# Altium schematic sheet wls2-inkScreen.SchDoc(wls2-inkScreen)
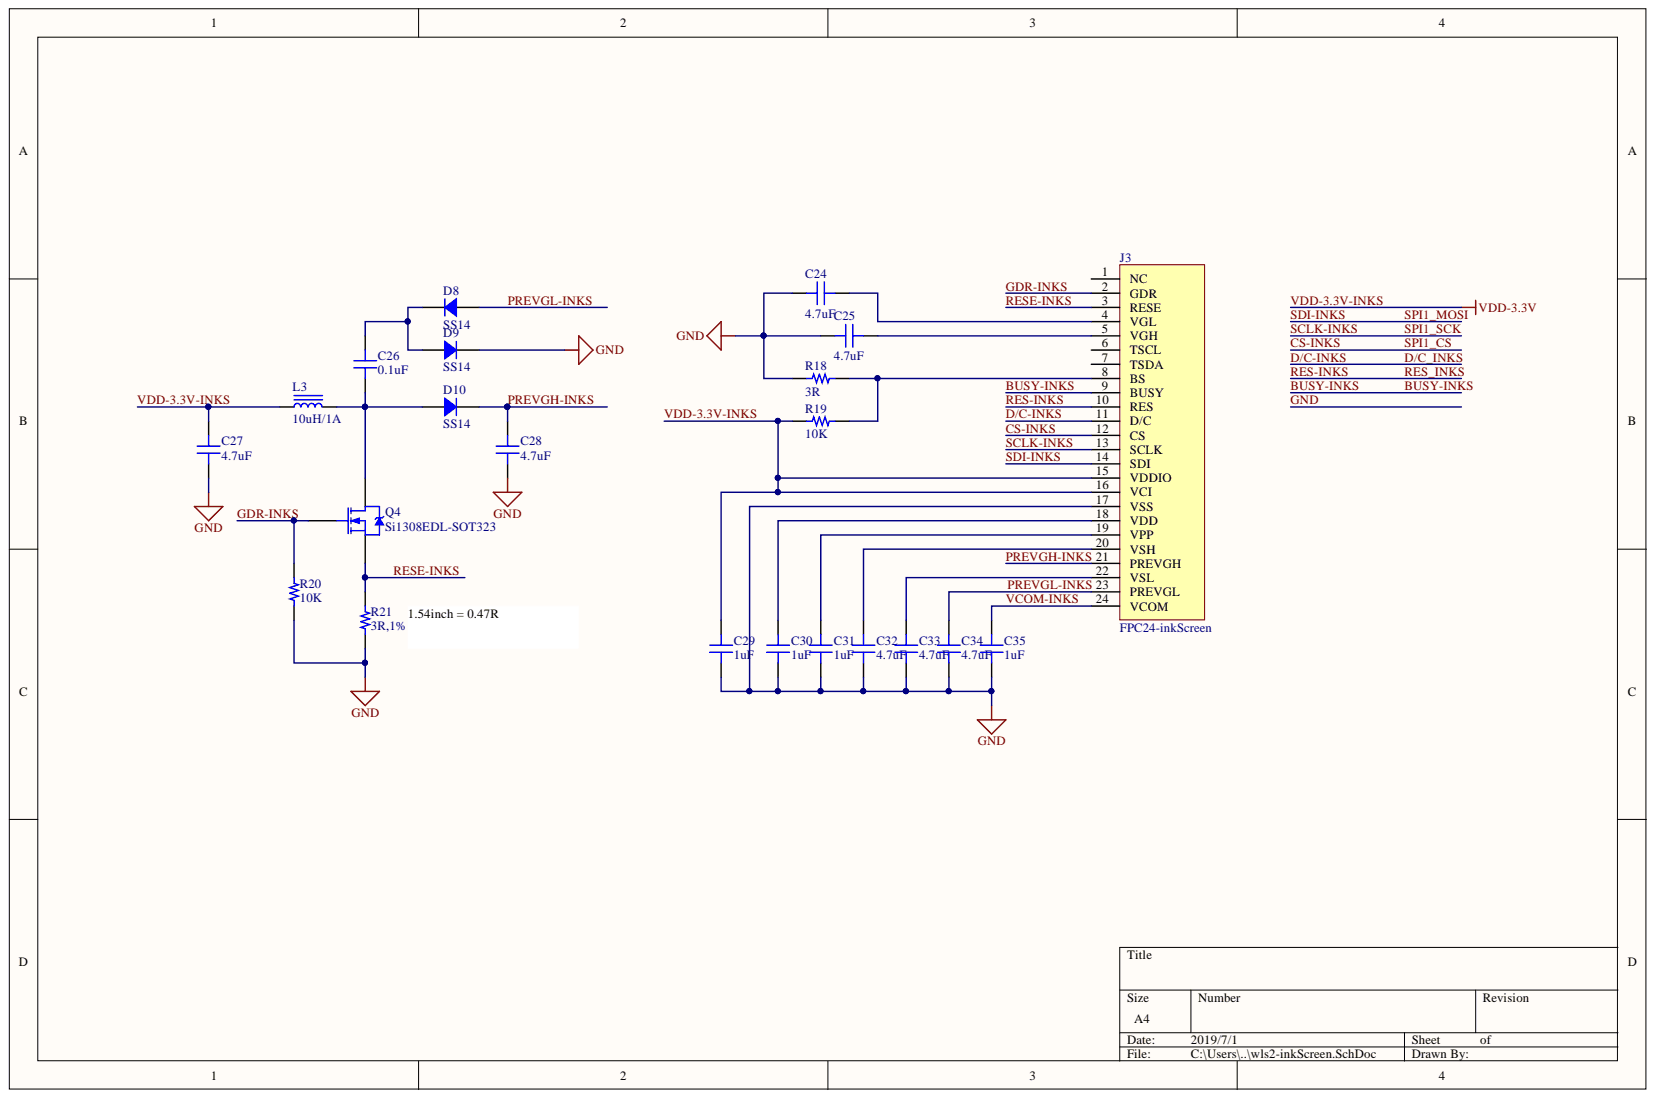
[diagram p0: wls2-inkScreen.SchDoc]

## Parts
C24  4.7uF  [RES 0402/1005]
C25  4.7uF  [RES 0402/1005]
C26  0.1uF  [RES 0402/1005]
C27  4.7uF  [RES 0402/1005]
C28  4.7uF  [RES 0402/1005]
C29  1uF  [RES 0402/1005]
C30  1uF  [RES 0402/1005]
C31  1uF  [RES 0402/1005]
C32  4.7uF  [RES 0402/1005]
C33  4.7uF  [RES 0402/1005]
C34  4.7uF  [RES 0402/1005]
C35  1uF  [RES 0402/1005]
D8  SS14  [SMA-DO214AC]
D9  SS14  [SMA-DO214AC]
D10  SS14  [SMA-DO214AC]
J3  FPC24-inkScreen  [FPC24-2-0.5]
L3  10uH/1A  [STWNR4018]
Q4  Si1308EDL-SOT323  [SOT23]
R18  3R  [RES 0402/1005]
R19  10K  [RES 0402/1005]
R20  10K  [RES 0402/1005]
R21  3R,1%  [RES 0402/1005]

## Nets
BUSY-INKS: J3.9(BUSY)
CS-INKS: J3.12(CS)
D/C-INKS: J3.11(D/C)
GDR-INKS: J3.2(GDR) Q4.1 R20.2
GND: C24.2 C25.2 C27.1 C28.1 C29.1 C30.1 C31.1 C32.1 C33.1 C34.1 C35.1 D9.2 J3.17(VSS) R18.1 R20.1 R21.1
PREVGH-INKS: C28.2 D10.2 J3.21(PREVGH)
PREVGL-INKS: C34.2 D8.1 J3.23(PREVGL)
RES-INKS: J3.10(RES)
RESE-INKS: J3.3(RESE) Q4.2 R21.2
SCLK-INKS: J3.13(SCLK)
SDI-INKS: J3.14(SDI)
VCOM-INKS: C35.2 J3.24(VCOM)
VDD-3.3V-INKS: C27.2 C29.2 J3.15(VDDIO) J3.16(VCI) L3.1 R19.1
NetC24_1: C24.1 J3.4(VGL)
NetC25_1: C25.1 J3.5(VGH)
NetC26_1: C26.1 D10.1 L3.2 Q4.3
NetC26_2: C26.2 D8.2 D9.1
NetC30_2: C30.2 J3.18(VDD)
NetC31_2: C31.2 J3.19(VPP)
NetC32_2: C32.2 J3.20(VSH)
NetC33_2: C33.2 J3.22(VSL)
NetJ3_8: J3.8(BS) R18.2 R19.2

Not connected: J3 1(NC) 6(TSCL) 7(TSDA)

## Library data of the parts
C24-C35 = Capacitor: SMT Capacitor; Component Kind: Standard; Library Name: Passives.SchLib
  C24 C25 C27 C28 C32-C34: Value: 4.7uF
  C26: Value: 0.1uF
  C29-C31 C35: Value: 1uF
D8-D10 = Diode: Rectifier Diode; Component Kind: Standard; Library Name: Diodes.SchLib; Part Number: SS14
J3 = FPC24-0.5mm: FPC24; Component Kind: Standard; Library Name: device_sch_lib.SchLib
L3 = Inductor: SMT Inductor; Component Kind: Standard; Library Name: Passives.SchLib; Value: 10uH/1A
Q4 = NMOS: Component Kind: Standard; Library Name: Footprint.SchLib
R18-R21 = Resistor: SMT Resistor; Component Kind: Standard; Library Name: Passives.SchLib
  R18: Value: 3R
  R19 R20: Value: 10K
  R21: Value: 3R,1%
